# Altium schematic sheet Sheet1.SchDoc(Sheet1)
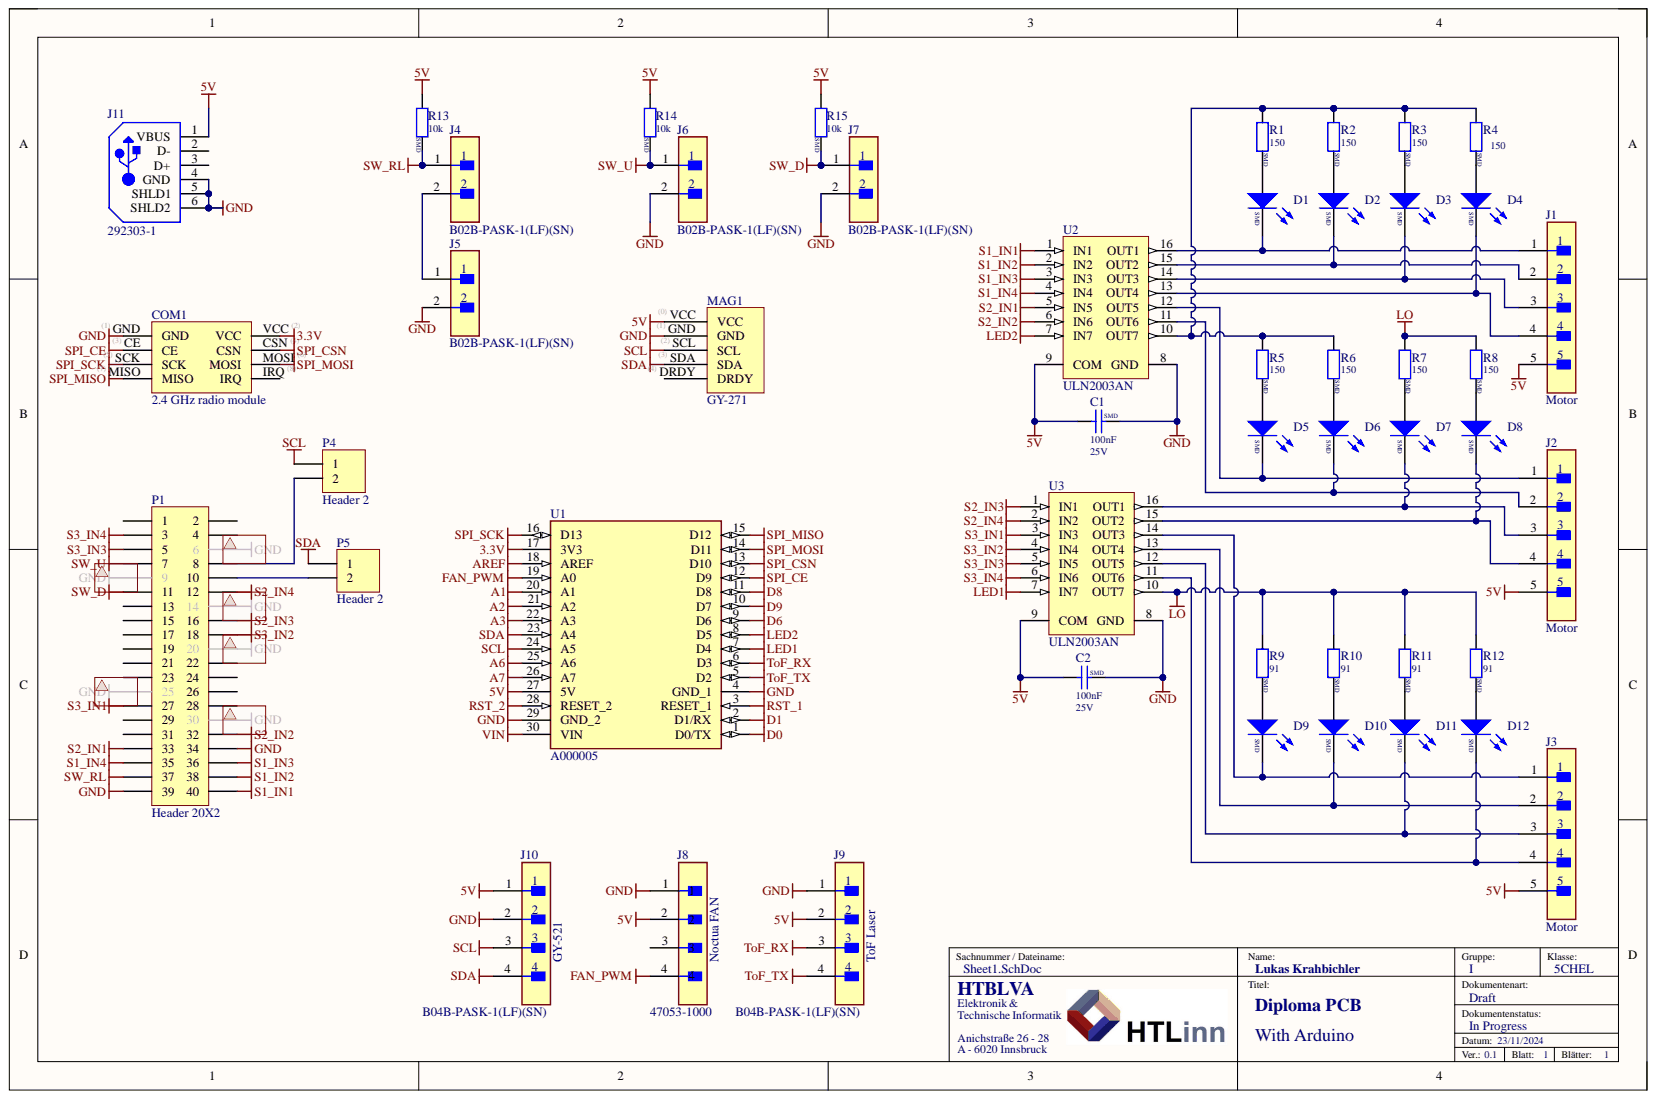
[diagram p0: Sheet1.SchDoc]

## Parts
C1  100nF  Rated Voltage: 25V  [C_SMD_C0402]
C2  100nF  Rated Voltage: 25V  [C_SMD_C0402]
COM1  2.4 GHz radio module  [nRF24L01]
D1  SMD LED RED  [SMD_0603_CHIP-LED]  (value not drawn)
D2  SMD LED RED  [SMD_0603_CHIP-LED]  (value not drawn)
D3  SMD LED RED  [SMD_0603_CHIP-LED]  (value not drawn)
D4  SMD LED RED  [SMD_0603_CHIP-LED]  (value not drawn)
D5  SMD LED GREEN  [SMD_0603_CHIP-LED]  (value not drawn)
D6  SMD LED GREEN  [SMD_0603_CHIP-LED]  (value not drawn)
D7  SMD LED GREEN  [SMD_0603_CHIP-LED]  (value not drawn)
D8  SMD LED GREEN  [SMD_0603_CHIP-LED]  (value not drawn)
D9  SMD LED BLUE  [SMD_0603_CHIP-LED]  (value not drawn)
D10  SMD LED BLUE  [SMD_0603_CHIP-LED]  (value not drawn)
D11  SMD LED BLUE  [SMD_0603_CHIP-LED]  (value not drawn)
D12  SMD LED BLUE  [SMD_0603_CHIP-LED]  (value not drawn)
J1  Motor  [FP-B5B-XH-AM_LF_SN-MFG]
J2  Motor  [FP-B5B-XH-AM_LF_SN-MFG]
J3  Motor  [FP-B5B-XH-AM_LF_SN-MFG]
J4  B02B-PASK-1(LF)(SN)  [FP-B02B-PASK-1_LF_SN-MFG]
J5  B02B-PASK-1(LF)(SN)  [FP-B02B-PASK-1_LF_SN-MFG]
J6  B02B-PASK-1(LF)(SN)  [FP-B02B-PASK-1_LF_SN-MFG]
J7  B02B-PASK-1(LF)(SN)  [FP-B02B-PASK-1_LF_SN-MFG]
J8  47053-1000  [FP-470531000-MFG]
J9  B04B-PASK-1(LF)(SN)  [FP-B04B-PASK-1_LF_SN-MFG]
J10  B04B-PASK-1(LF)(SN)  [FP-B04B-PASK-1_LF_SN-MFG]
J11  292303-1  [TE-292303-1_V]
MAG1  GY-271  [GY271]
P1  Header 20X2  [HDR2X20]
P4  Header 2  [HDR1X2]
P5  Header 2  [HDR1X2]
R1  150  [R_SMD_R0402]
R2  150  [R_SMD_R0402]
R3  150  [R_SMD_R0402]
R4  150  [R_SMD_R0402]
R5  150  [R_SMD_R0402]
R6  150  [R_SMD_R0402]
R7  150  [R_SMD_R0402]
R8  150  [R_SMD_R0402]
R9  91  [R_SMD_R0402]
R10  91  [R_SMD_R0402]
R11  91  [R_SMD_R0402]
R12  91  [R_SMD_R0402]
R13  10k  [R_SMD_R0402]
R14  10k  [R_SMD_R0402]
R15  10k  [R_SMD_R0402]
U1  A000005  [MODULE_A000005]
U2  ULN2003AN  [STM-DIP-16L]
U3  ULN2003AN  [STM-DIP-16L]

## Nets
3.3V: COM1.2(VCC) U1.17(3V3)
5V: C1.1 C2.1 J1.5 J2.5 J3.5 J8.2 J9.2 J10.1 J11.1(VBUS) MAG1.0(VCC) R13.1 R14.1 R15.1 U1.27(5V) U2.9(COM) U3.9(COM)
A1: U1.20(A1)
A2: U1.21(A2)
A3: U1.22(A3)
A6: U1.25(A6)
A7: U1.26(A7)
AREF: U1.18(AREF)
D0: U1.1(D0/TX)
D1: U1.2(D1/RX)
D6: U1.9(D6)
D8: U1.11(D8)
D9: U1.10(D7)
FAN_PWM: J8.4 U1.19(A0)
GND: C1.2 C2.2 COM1.1(GND) J5.2 J6.2 J7.2 J8.1 J9.1 J10.2 J11.4(GND) J11.5(SHLD1) J11.6(SHLD2) MAG1.1(GND) P1.34 P1.39 U1.4(GND_1) U1.29(GND_2) U2.8(GND) U3.8(GND)
LED1: U1.7(D4) U3.7(IN7)
LED2: U1.8(D5) U2.7(IN7)
LO: R7.1 R8.1 R9.1 R10.1 R11.1 R12.1 U3.10(OUT7)
RST_1: U1.3(RESET_1)
RST_2: U1.28(RESET_2)
S1_IN1: P1.40 U2.1(IN1)
S1_IN2: P1.38 U2.2(IN2)
S1_IN3: P1.36 U2.3(IN3)
S1_IN4: P1.35 U2.4(IN4)
S2_IN1: P1.33 U2.5(IN5)
S2_IN2: P1.32 U2.6(IN6)
S2_IN3: P1.16 U3.1(IN1)
S2_IN4: P1.12 U3.2(IN2)
S3_IN1: P1.27 U3.3(IN3)
S3_IN2: P1.18 U3.4(IN4)
S3_IN3: P1.5 U3.5(IN5)
S3_IN4: P1.3 U3.6(IN6)
SCL: J10.3 MAG1.2(SCL) P4.1 U1.24(A5)
SDA: J10.4 MAG1.3(SDA) P5.1 U1.23(A4)
SPI_CE: COM1.3(CE) U1.12(D9)
SPI_CSN: COM1.4(CSN) U1.13(D10)
SPI_MISO: COM1.7(MISO) U1.15(D12)
SPI_MOSI: COM1.6(MOSI) U1.14(D11)
SPI_SCK: COM1.5(SCK) U1.16(D13)
SW_D: J7.1 P1.11 R15.2
SW_RL: J4.1 P1.37 R13.2
SW_U: J6.1 P1.7 R14.2
ToF_RX: J9.3 U1.6(D3)
ToF_TX: J9.4 U1.5(D2)
VIN: U1.30(VIN)
NetD1_A: D1.A R1.2
NetD1_K: D1.K J1.1 U2.16(OUT1)
NetD2_A: D2.A R2.2
NetD2_K: D2.K J1.2 U2.15(OUT2)
NetD3_A: D3.A R3.2
NetD3_K: D3.K J1.3 U2.14(OUT3)
NetD4_A: D4.A R4.2
NetD4_K: D4.K J1.4 U2.13(OUT4)
NetD5_A: D5.A R5.2
NetD5_K: D5.K J2.1 U2.12(OUT5)
NetD6_A: D6.A R6.2
NetD6_K: D6.K J2.2 U2.11(OUT6)
NetD7_A: D7.A R7.2
NetD7_K: D7.K J2.3 U3.16(OUT1)
NetD8_A: D8.A R8.2
NetD8_K: D8.K J2.4 U3.15(OUT2)
NetD9_A: D9.A R9.2
NetD9_K: D9.K J3.1 U3.14(OUT3)
NetD10_A: D10.A R10.2
NetD10_K: D10.K J3.2 U3.13(OUT4)
NetD11_A: D11.A R11.2
NetD11_K: D11.K J3.3 U3.12(OUT5)
NetD12_A: D12.A R12.2
NetD12_K: D12.K J3.4 U3.11(OUT6)
NetJ4_2: J4.2 J5.1
NetP1_8: P1.8 P4.2
NetP1_10: P1.10 P5.2
NetR1_1: R1.1 R2.1 R3.1 R4.1 R5.1 R6.1 U2.10(OUT7)

Not connected: COM1 8(IRQ); J8 3; J11 2(D-) 3(D+); MAG1 4(DRDY); P1 1 2 4 13 15 17 19 21 22 23 24 26 28 29 31

## Library data of the parts
C1 C2 = C_SMD: SMD Capacitor; Usage: only permitted for non-commercial purposes at HTL I Innsbruck; Component Kind: Standard; LatestRevisionDate: 07-Sep-2019; Library Name: HTLInn_RLCQ.IntLib; Published: 21-Feb-2011; Signal Integrity: C_SMD; Simulation: C_SMD; Value: 100nF
COM1 = nRF24L01: Header, 4-Pin, Dual row; Component Kind: Standard; LatestRevisionDate: 17-Jul-2002; LatestRevisionNote: Re-released for DXP Platform.; Library Name: Diploma_Library.IntLib; Publisher: Altium Limited
D1-D4 = SMD_LED_RED: Red GaAs LED (Vf=2V, If=20mA); SimulationModellSource: Miscellaneous Devices; Usage: only permitted for non-commercial purposes at HTL I Innsbruck; Component Kind: Standard; LatestRevisionDate: 21-Jun-2015; Published: 29-Mar-2017; Simulation: LED_RED; Library Name: HTLinn_Opto.IntLib
D5-D8 = SMD_LED_GREEN: Green GaAs LED (Vf=2V, If=20mA); SimulationModellSource: Miscellaneous Devices; Usage: only permitted for non-commercial purposes at HTL I Innsbruck; Component Kind: Standard; LatestRevisionDate: 21-Jun-2015; Published: 29-Mar-2017; Simulation: LED_GRN_YEL; Library Name: HTLinn_Opto.IntLib
D9-D12 = SMD_LED_BLUE: Blue SiC LED (Vf=3.2V, If=20mA); SimulationModellSource: Miscellaneous Devices; Usage: only permitted for non-commercial purposes at HTL I Innsbruck; Component Kind: Standard; LatestRevisionDate: 21-Jun-2015; Published: 29-Mar-2017; Simulation: LED_BLUE; Library Name: HTLinn_Opto.IntLib
J1-J3 = CMP-17439-000304-1: CONN HEADER VERT 5POS 2.5MM; Contact Resistance: 20mR; Mated Stacking Heights: 9.8mm; Max Current Rating: 3A; Max Voltage Rating (AC): 250V; Number of Positions: 5; Color: Green; Component Kind: Standard; Connector Type: Header; Contact Finish - Mating: TIN; Contact Material: Brass; Contact Plating: Copper; Contact Shape: Square; Contact Type: Male Pin; Depth: 5.75mm; Fastening Type: Detent; Flammability Rating: UL94 V-0; Housing Color: White; Housing Material: Polyamide; Insulation Color: Natural; Insulation Material: Nylon; Lead Free: Lead Free; Length: 14.9mm; Library Name: Altium Content Vault; Max Operating Temperature: 85°C; Min Operating Temperature: -25°C; Mount: Through Hole; Number of Rows: 1; Orientation: Straight; Packaging: Bulk; Pitch: 2.5mm; Series: XH; Termination: Solder; Voltage Rating: 250V
J4-J7 = CMP-17439-000415-1: CONN HEADER VERT 2POS 2MM; Current Rating: 3A; Gender: Male; Insulation Resistance: 1GR; Mated Stacking Heights: 14.9mm; Number of Contacts: 2; Voltage Rating: 250V; Color: Natural; Component Kind: Standard; Connector Type: Header; Contact Finish - Mating: TIN; Contact Material: Copper Alloy; Contact Mating Length: 0.126inch; Contact Plating: Tin; Contact Shape: Square; Contact Type: Male Pin; Fastening Type: Ramp; Flammability Rating: UL94 V-0; Housing Color: White; Insulation Color: Natural; Insulation Material: PBT; Lead Free: Lead Free; Library Name: Altium Content Vault; Material: Polybutylene; Max Operating Temperature: 85°C; Min Operating Temperature: -25°C; Mount: Through Hole; Number of Positions: 2; Number of Rows: 1; Orientation: Straight; Packaging: Bulk; Pitch: 2mm; Series: PA; Termination: Solder
J8 = CMP-04776-000290-2: CONN HEADER VERT 4POS 2.54MM; Manufacturer Lifecycle Status: ACTIVE; NexarPartId: 854935; Current Rating: 500mA; Gender: Male; Glow Wire Compliant: Non-compliant; Number of Circuits: 4; Plating: Copper; Voltage Rating: 250V; Ambient Temperature Range High: 105°C; Component Kind: Standard; Contact Material: Brass, Copper; Contact Plating: Tin; Country of Origin: HK; Depth: 5.84mm; Halogen Free: Low Halogen; Height: 7.7mm; Housing Color: Beige; Housing Material: Nylon; Lead Pitch: 2.54mm; Length: 10.2mm; Library Name: Altium Content Vault; Lifecycle Status: Production; Max Operating Temperature: 75°C; Min Operating Temperature: 0°C; Mount: Through Hole; Number of Contacts: 4; Number of Pins: 4; Number of Positions: 4; Number of Rows: 1; Orientation: Vertical; Packaging: Bulk; Pitch: 2.54mm; Radiation Hardening: No; REACH SVHC: Unknown; RoHS: Compliant; Schedule B: 8536694040, 8536694040; Termination: Through Hole; Voltage Rating (DC): 250V
J9 J10 = CMP-17439-000192-1: CONN HEADER VERT 4POS 2MM; Contact Resistance: 20mR; Current Rating: 3A; Gender: Male; Mated Stacking Heights: 14.9mm; Max Voltage Rating (AC): 250V; Number of Contacts: 4; Color: Natural; Component Kind: Standard; Connector Type: Header; Contact Finish - Mating: TIN; Contact Material: Copper Alloy; Contact Mating Length: 0.126inch; Contact Plating: Copper; Contact Shape: Square; Contact Type: Male Pin; Depth: 5.3mm; Fastening Type: Ramp; Flammability Rating: UL94 V-0; Housing Color: White; Insulation Color: Natural; Insulation Material: PBT; Lead Free: Lead Free; Length: 10mm; Library Name: Altium Content Vault; Max Current Rating: 3A; Max Operating Temperature: 85°C; Min Operating Temperature: -25°C; Mount: Through Hole; Number of Positions: 4; Number of Rows: 1; Orientation: Straight; Packaging: Bulk; Pitch: 2mm; Series: PA; Termination: Solder; Voltage Rating: 250V
J11 = CMP-2000-05404-1: 1 Port Right Angle Through Hole Mount Female Type A USB Connector, 4 Position, -55 to 85 degC, RoHS, Tube; Manufacturer: TE Connectivity; Connector Mounting: PCB; Connector Type: USBA; Contact Termination Type: Solder; Gender: Receptacle; No. of Contacts: 4; SVHC: NoSVHC(16-Jun-2014); Component Kind: Standard; Contact Material: Copper; Contact Plating: Gold; Datasheet URL: http://www.farnell.com/cad/1653030.pdf; Datasheet Version: Feb-12; Library Name: Altium Content Vault; Manufacturer URL: http://www.te.com/; Package Description: 4-Pin Through Hole Device, Body 14 x 13.13 mm, Pitch 2.5 mm; Package Reference: 292303-1
MAG1 = GY271: I2C Compass Module Compass Magnet Sensor; Component Kind: Standard; Library Name: Diploma_Library.IntLib
P1 = Header 20X2: Header, 20-Pin, Dual row; Component Kind: Standard; LatestRevisionDate: 17-Jul-2002; LatestRevisionNote: Re-released for DXP Platform.; Library Name: Miscellaneous Connectors.IntLib; Signal Integrity: Connector; Publisher: Altium Limited
P4 P5 = Header 2: Header, 2-Pin; Component Kind: Standard; LatestRevisionDate: 17-Jul-2002; LatestRevisionNote: Re-released for DXP Platform.; Library Name: Miscellaneous Connectors.IntLib; Signal Integrity: Connector; Publisher: Altium Limited
R1-R15 = R_SMD: SMD Resistor; Usage: only permitted for non-commercial purposes at HTL I Innsbruck; Component Kind: Standard; LatestRevisionDate: 07-Sep-2019; Library Name: HTLInn_RLCQ.IntLib; Published: 21-Feb-2011; Signal Integrity: R_SMD; Simulation: R_SMD
  R1-R8: Value: 150
  R9-R12: Value: 91
  R13-R15: Value: 10k
U1 = A000005: Small, complete, and breadboard-friendly board based on the ATmega328 (Arduino Nano 3.x).; Availability: In Stock; Check_prices: https://www.snapeda.com/parts/A000005/Arduino/view-part/?ref=eda; Component Kind: Standard; Library Name: A000005.IntLib; MF: Arduino; MP: A000005; Package: Non-Standard Arduino; Price: None; Purchase-URL: https://pricing.snapeda.com/search/part/A000005/?ref=eda; SnapEDA_Link: https://www.snapeda.com/parts/A000005/Arduino/view-part/?ref=snap
U2 U3 = CMP-2000-07351-1: Seven Darlington Array, 50 V, 0.5 A, -40 to 85 degC, 16-Pin DIP, RoHS, Tube; Manufacturer: STMicroelectronics; Collector Emitter Voltage V(br)ceo: 50V; DC Collector Current: 500mA; DC Current Gain hFE: 1000hFE; No. of Pins: 16Pins; Output Current: 0.5A; SVHC: NoSVHC(17-Dec-2015); Transistor Polarity: NPN; Automotive Qualification Standard: -; Component Kind: Standard; Datasheet URL: http://www2.st.com/content/ccc/resource/technical/document/datasheet/f3/6e/c8/64/4d/b3/4e/38/CD00001244.pdf/files/CD00001244.pdf/jcr:content/translations/en.CD00001244.pdf; Datasheet Version: Rev. 8, 06/2012; Library Name: Altium Content Vault; Manufacturer URL: http://www.st.com/; Mounting Technology: ThroughHole; MSL: MSL2-1year; Operating Temperature Max: 85°C; Package Description: 16-Pin DIP, Body 19.7 x 7.1 mm, Pitch 2.54 mm; Package Reference: DIP-16L; PartNumber: ULN2003A; Power Dissipation Pd: -; Product Range: -; Transistor Case Style: DIP; VOUT: 50V
